# Altium schematic sheet 06 RS232.SchDoc
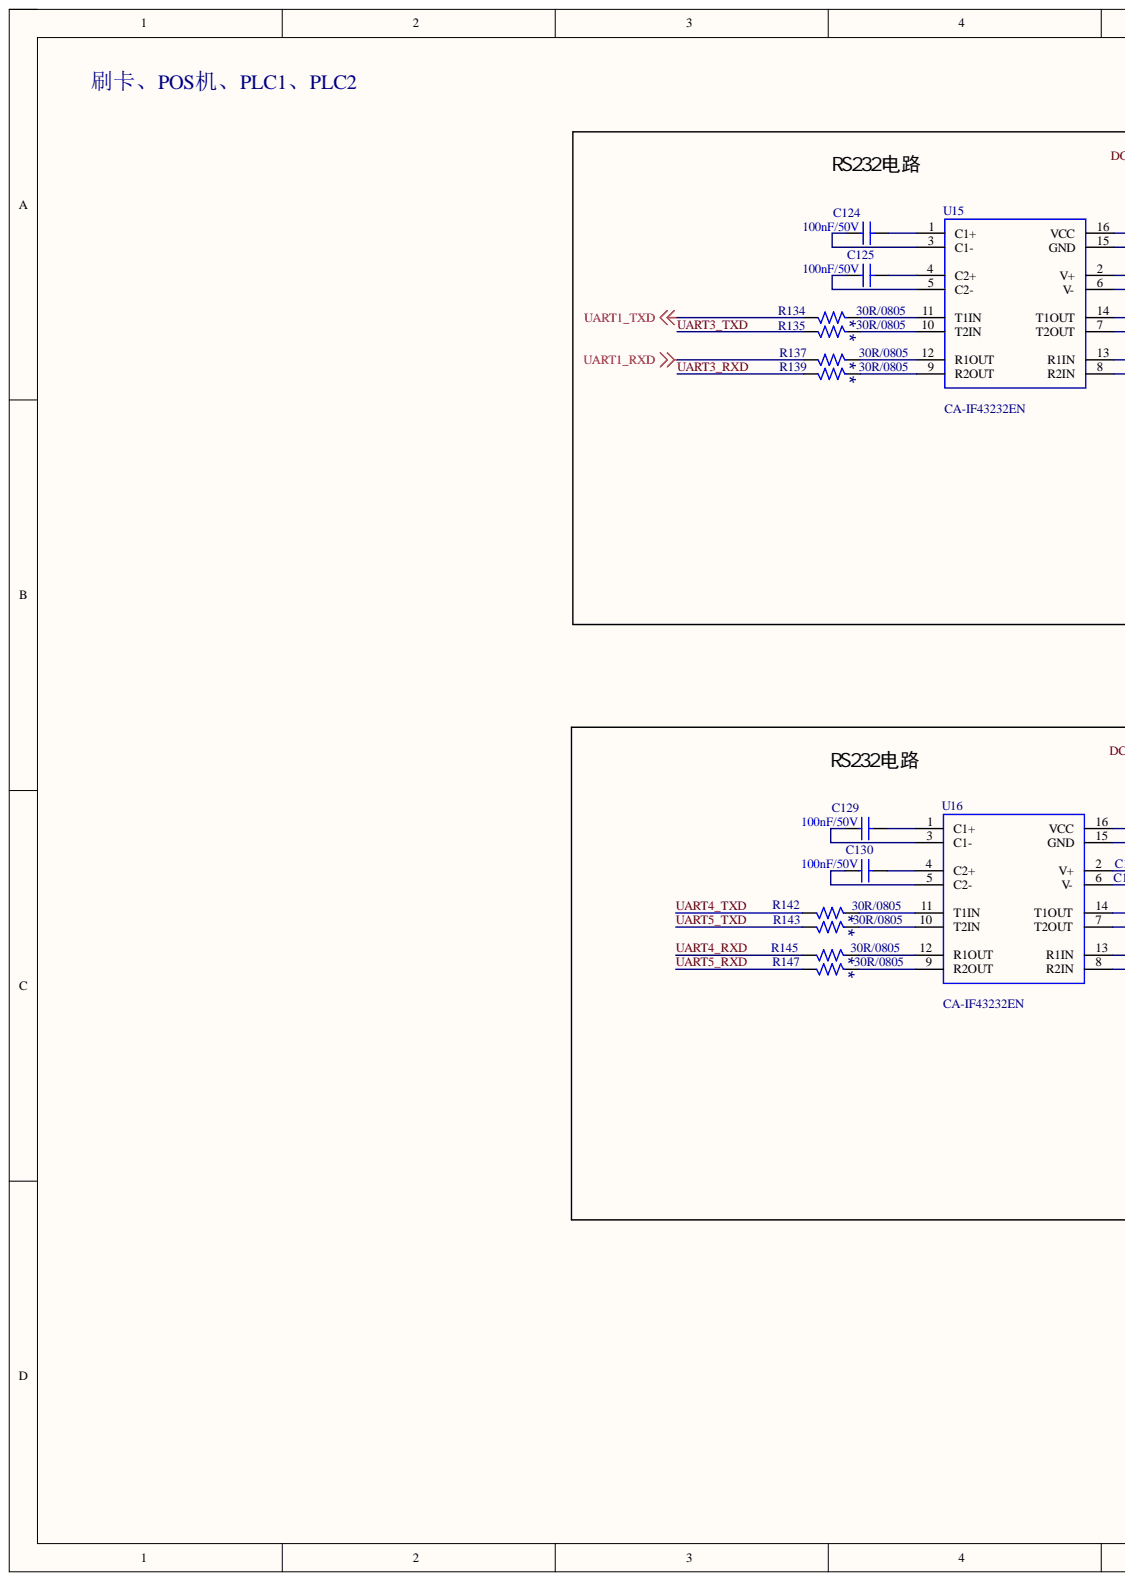
[diagram p0: 06 RS232.SchDoc, left]
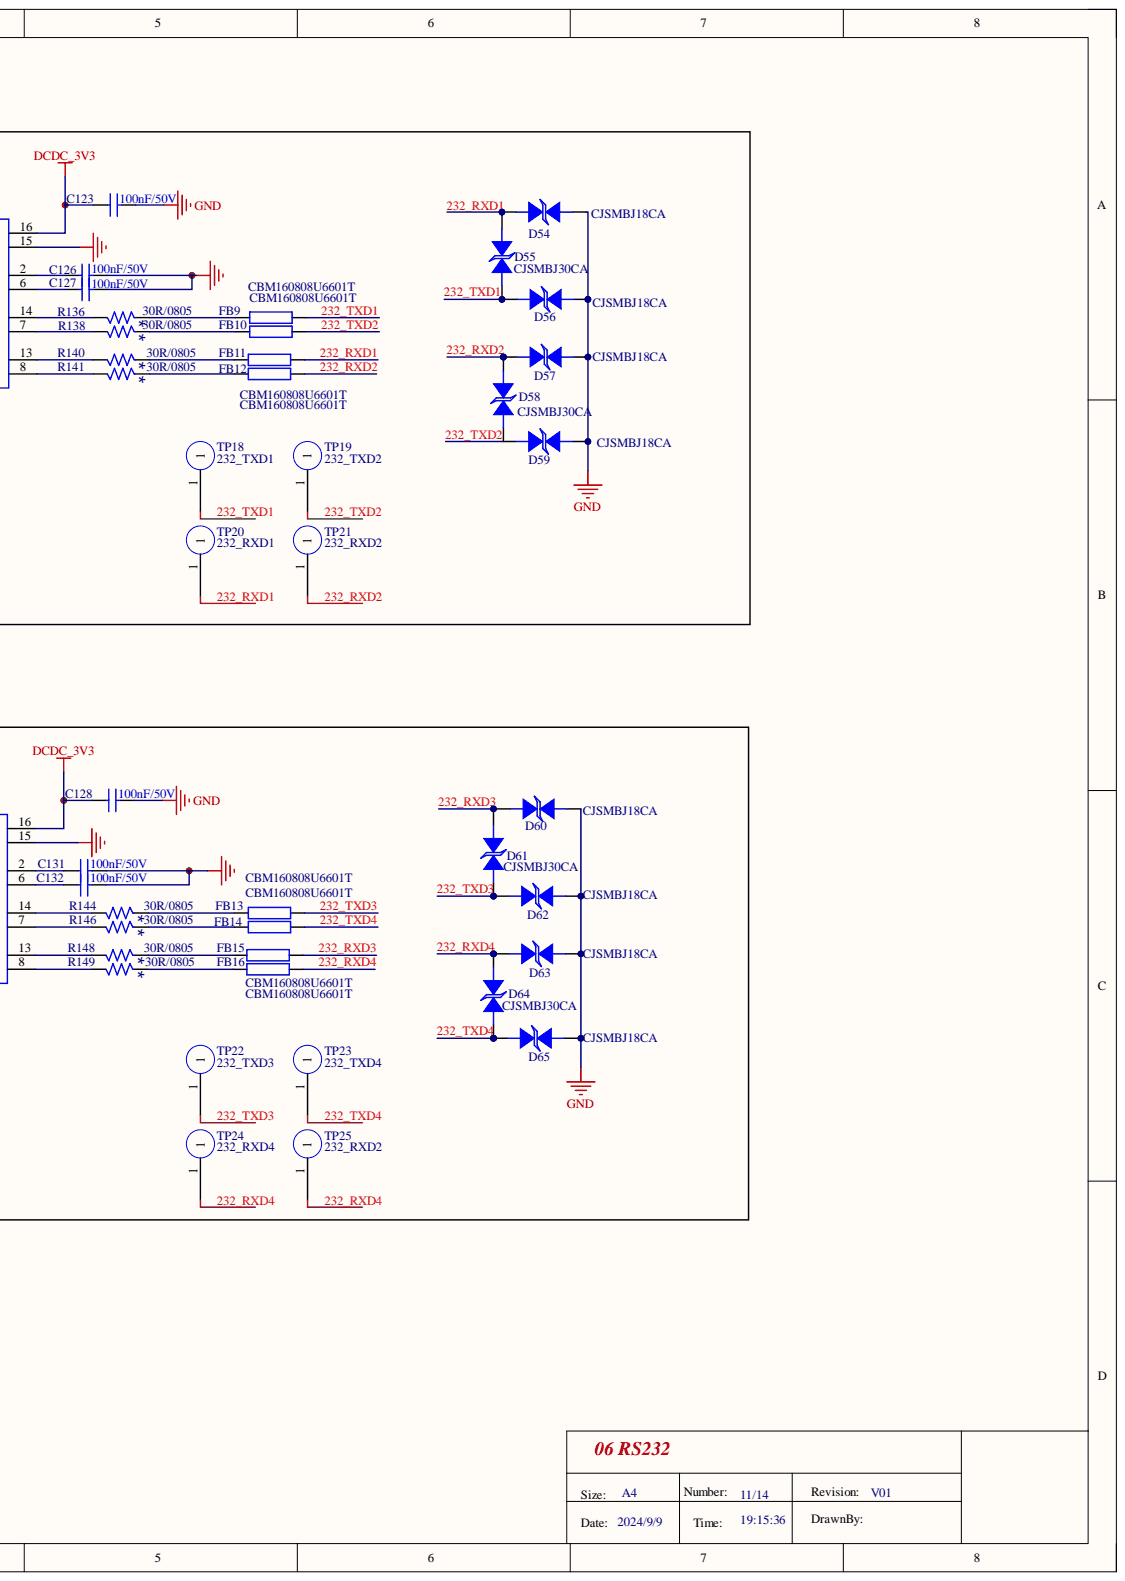
[diagram p1: 06 RS232.SchDoc, right]

## Parts
C123  100nF/50V  [c0805h14]
C124  100nF/50V  [c0805h14]
C125  100nF/50V  [c0805h14]
C126  100nF/50V  [c0805h14]
C127  100nF/50V  [c0805h14]
C128  100nF/50V  [c0805h14]
C129  100nF/50V  [c0805h14]
C130  100nF/50V  [c0805h14]
C131  100nF/50V  [c0805h14]
C132  100nF/50V  [c0805h14]
D54  CJSMBJ18CA  [SMA]
D55  CJSMBJ30CA  [SMA]
D56  CJSMBJ18CA  [SMA]
D57  CJSMBJ18CA  [SMA]
D58  CJSMBJ30CA  [SMA]
D59  CJSMBJ18CA  [SMA]
D60  CJSMBJ18CA  [SMA]
D61  CJSMBJ30CA  [SMA]
D62  CJSMBJ18CA  [SMA]
D63  CJSMBJ18CA  [SMA]
D64  CJSMBJ30CA  [SMA]
D65  CJSMBJ18CA  [SMA]
FB9  CBM160808U6601T  [r0805h6]
FB10  CBM160808U6601T  [r0805h6]
FB11  CBM160808U6601T  [r0805h6]
FB12  CBM160808U6601T  [r0805h6]
FB13  CBM160808U6601T  [r0805h6]
FB14  CBM160808U6601T  [r0805h6]
FB15  CBM160808U6601T  [r0805h6]
FB16  CBM160808U6601T  [r0805h6]
R134  30R/0805  [R0805H6]
R135  30R/0805  [R0805H6]
R136  30R/0805  [R0805H6]
R137  30R/0805  [R0805H6]
R138  30R/0805  [R0805H6]
R139  30R/0805  [R0805H6]
R140  30R/0805  [R0805H6]
R141  30R/0805  [R0805H6]
R142  30R/0805  [R0805H6]
R143  30R/0805  [R0805H6]
R144  30R/0805  [R0805H6]
R145  30R/0805  [R0805H6]
R146  30R/0805  [R0805H6]
R147  30R/0805  [R0805H6]
R148  30R/0805  [R0805H6]
R149  30R/0805  [R0805H6]
TP18  232_TXD1  [TP12]
TP19  232_TXD2  [TP12]
TP20  232_RXD1  [TP12]
TP21  232_RXD2  [TP12]
TP22  232_TXD3  [TP12]
TP23  232_TXD4  [TP12]
TP24  232_RXD4  [TP12]
TP25  232_RXD2  [TP12]
U15  CA-IF43232EN  [SOIC-16_150mil]
U16  CA-IF43232EN  [SOIC-16_150mil]

## Nets
DCDC_3V3: C123.1 C128.1 U15.16(VCC) U16.16(VCC)
GND: C123.2 C126.2 C127.2 C128.2 C131.2 C132.2 D54.2 D56.2 D57.2 D59.2 D60.2 D62.2 D63.2 D65.2 U15.15(GND) U16.15(GND)
UART1_RXD: R137.2
UART1_TXD: R134.2
232_RXD1: D54.1 D55.1 FB11.2 TP20.1
232_RXD2: D57.1 D58.1 FB12.2 TP21.1
232_RXD3: D60.1 D61.1 FB15.2
232_RXD4: D63.1 D64.1 FB16.2 TP24.1 TP25.1
232_TXD1: D55.2 D56.1 FB9.2 TP18.1
232_TXD2: D59.1 FB10.2 TP19.1
232_TXD3: D61.2 D62.1 FB13.2 TP22.1
232_TXD4: D64.2 D65.1 FB14.2 TP23.1
UART3_RXD: R139.2
UART3_TXD: R135.2
UART4_RXD: R145.2
UART4_TXD: R142.2
UART5_RXD: R147.2
UART5_TXD: R143.2
NetC124_1: C124.1 U15.3(C1-)
NetC124_2: C124.2 U15.1(C1+)
NetC125_1: C125.1 U15.5(C2-)
NetC125_2: C125.2 U15.4(C2+)
NetC126_1: C126.1 U15.2(V+)
NetC127_1: C127.1 U15.6(V-)
NetC129_1: C129.1 U16.3(C1-)
NetC129_2: C129.2 U16.1(C1+)
NetC130_1: C130.1 U16.5(C2-)
NetC130_2: C130.2 U16.4(C2+)
NetC131_1: C131.1 U16.2(V+)
NetC132_1: C132.1 U16.6(V-)
NetFB9_1: FB9.1 R136.1
NetFB10_1: FB10.1 R138.1
NetFB11_1: FB11.1 R140.1
NetFB12_1: FB12.1 R141.1
NetFB13_1: FB13.1 R144.1
NetFB14_1: FB14.1 R146.1
NetFB15_1: FB15.1 R148.1
NetFB16_1: FB16.1 R149.1
NetR134_1: R134.1 U15.11(T1IN)
NetR135_1: R135.1 U15.10(T2IN)
NetR136_2: R136.2 U15.14(T1OUT)
NetR137_1: R137.1 U15.12(R1OUT)
NetR138_2: R138.2 U15.7(T2OUT)
NetR139_1: R139.1 U15.9(R2OUT)
NetR140_2: R140.2 U15.13(R1IN)
NetR141_2: R141.2 U15.8(R2IN)
NetR142_1: R142.1 U16.11(T1IN)
NetR143_1: R143.1 U16.10(T2IN)
NetR144_2: R144.2 U16.14(T1OUT)
NetR145_1: R145.1 U16.12(R1OUT)
NetR146_2: R146.2 U16.7(T2OUT)
NetR147_1: R147.1 U16.9(R2OUT)
NetR148_2: R148.2 U16.13(R1IN)
NetR149_2: R149.2 U16.8(R2IN)

Not connected: D58 2

## Library data of the parts
C123-C132 = 30pF-X7R-50V-0805_3_Dup1: Tolerance: 50V,X7R,+/-10%; Component Kind: Standard; Library Name: 原理图库.SCHLIB; PCB Footprint: c0805h14
D54-D65 = DIODE TVS BI-DIR_6: PCB Footprint: SMBG; Component Kind: Standard; Library Name: 原理图库.SCHLIB
FB9-FB16 = R_1_Dup4_1: Component Kind: Standard; Library Name: 原理图库.SCHLIB; PCB Footprint: r0805h6
R134-R149 = RC0402JR-0710KL: RES,10KOhm,+/-5%,1/16W,G,SMD0402; Foxconn Part Number: 610107C00-011-G; Part Number: 61-01000006-03-011; Approval Status: A; CCL: LF; CD: 100; CR6: 1000; Green State: C5; HHPN: 61-01000006-03-011-G; KEYID: 4998; Mfg: VPN; ONLY_DATASHEETNAME: YAGEO-RC0402JR-0710KL-DS.pdf; PCB_FOOTPRINT_BEFORE: r0603h4; Relex Category: 3; Relex Subcategory: 2; Remark: Reserved; VPN: 610107C00-VPN-G; Component Kind: Standard; HG: 1000; Library Name: 原理图库.SCHLIB; Mfg Part Number: VPN; Package Type: SMD; Part Type: VPN\ROHS\Resistor\Chip Resistor; PB: 1000; PBB: 1000; PBDE: 1000; PCB Footprint: R0805H6; RELATED_PARTS: http://ytpdm.efoxconn.com/Windchill/netmarkets/jsp/ext/ytpdm/bom/cis/searchManufacturePart1.jsp?strVPartNumber=610107C00-VPN-G; Sub System: Reserved; Tolerance: +/-5%
TP18-TP25 = PAD: Component Kind: Standard; PCB Footprint: TP12
U15 U16 = MAX3232E: PARTS: 1; PKG_TYPE: SOP16-26-208-255; Component Kind: Standard; Library Name: Schlib1.SchLib
